# Altium schematic sheet PowerSupply.SchDoc(PowerSupply)
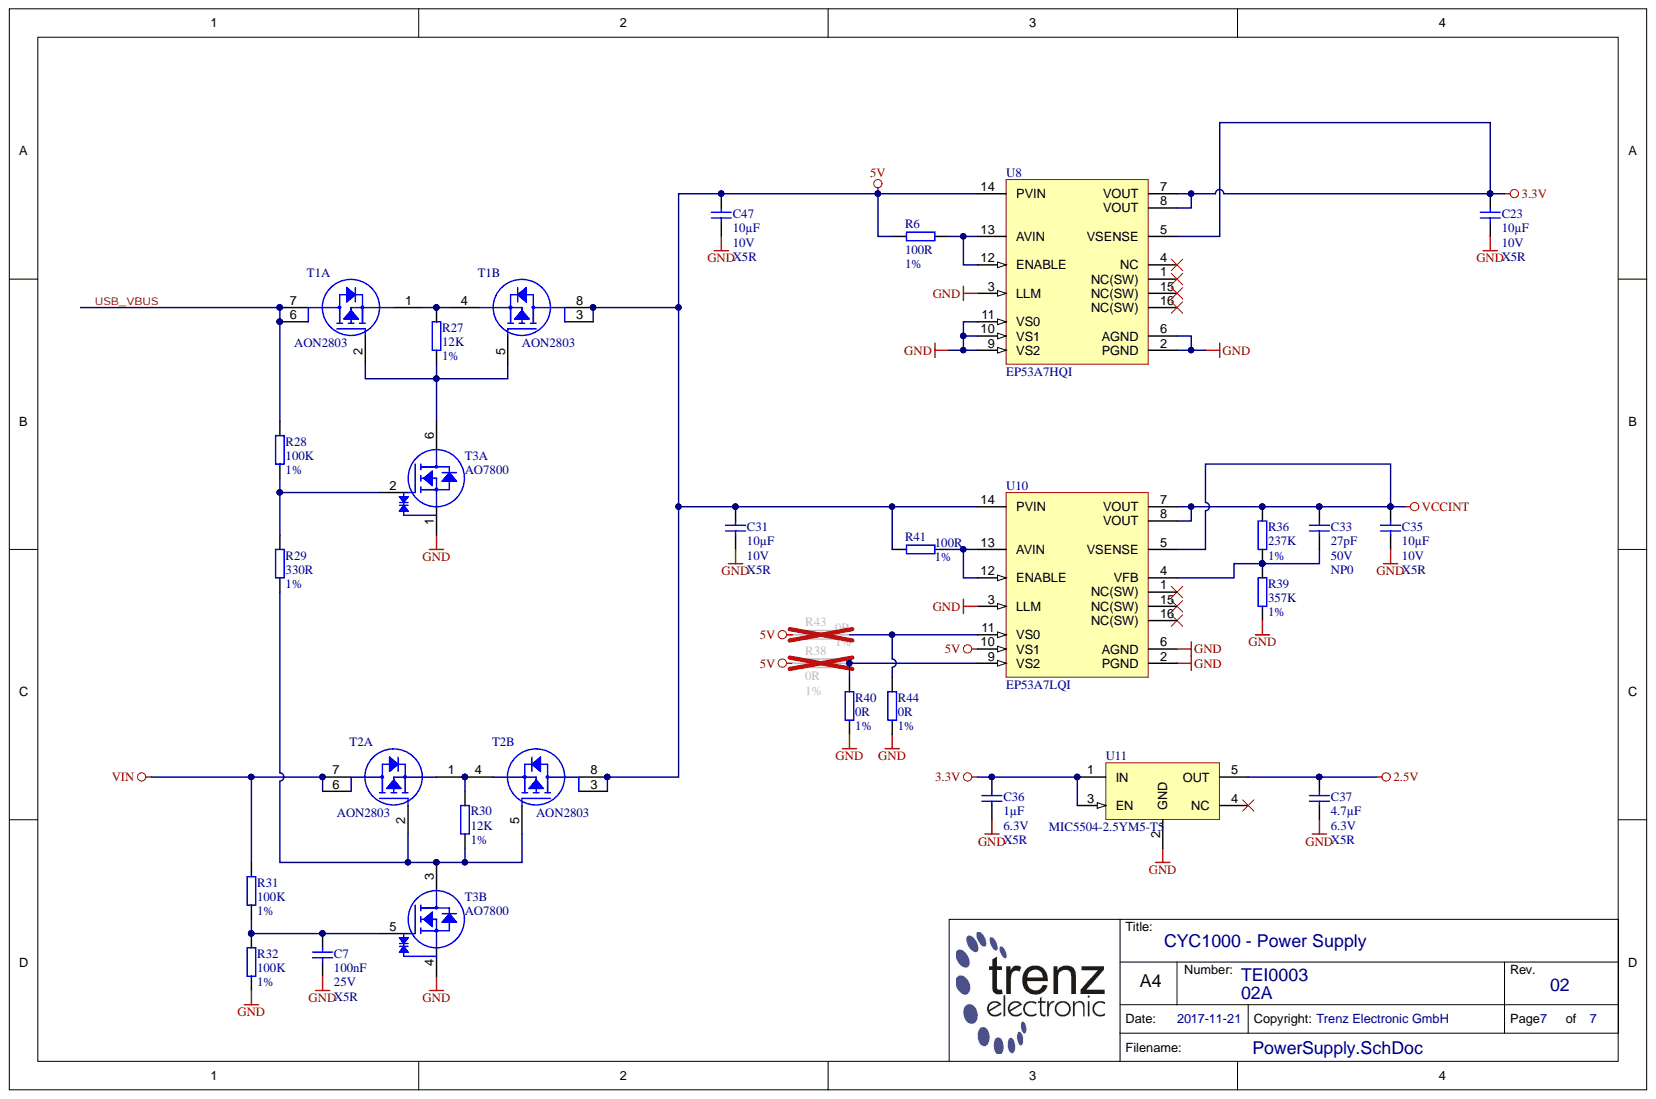
[diagram p0: PowerSupply.SchDoc]

## Parts
C7  100nF  Technology: X5R  Voltage: 25V  [CAPC1005X55N]
C23  10µF  Technology: X5R  Voltage: 10V  [CAPC2012X135N]
C31  10µF  Technology: X5R  Voltage: 10V  [CAPC2012X135N]
C33  27pF  Technology: NP0  Voltage: 50V  [CAPC1005X55N]
C35  10µF  Technology: X5R  Voltage: 10V  [CAPC2012X135N]
C36  1µF  Technology: X5R  Voltage: 6.3V  [CAPC1005X55N]
C37  4.7µF  Technology: X5R  Voltage: 6.3V  [CAPC1005X60N]
C47  10µF  Technology: X5R  Voltage: 10V  [CAPC2012X135N]
R6  100R  Tolerance: 1%  [RESC1005X40N]
R27  12K  Tolerance: 1%  [RESC1005X40N]
R28  100K  Tolerance: 1%  [RESC1005X40N]
R29  330R  Tolerance: 1%  [RESC1005X40N]
R30  12K  Tolerance: 1%  [RESC1005X40N]
R31  100K  Tolerance: 1%  [RESC1005X40N]
R32  100K  Tolerance: 1%  [RESC1005X40N]
R36  237K  Tolerance: 1%  [RESC1005X40N]
R38  0R  Tolerance: 1%  [RESC1005X40N]
R39  357K  Tolerance: 1%  [RESC1005X40N]
R40  0R  Tolerance: 1%  [RESC1005X40N]
R41  100R  Tolerance: 1%  [RESC1005X40N]
R43  0R  Tolerance: 1%  [RESC1005X40N]
R44  0R  Tolerance: 1%  [RESC1005X40N]
T1  AON2803  [SON65P200X200X80-8T10X06]  (parts A,B)
T2  AON2803  [SON65P200X200X80-8T10X06]  (parts A,B)
T3  AO7800  [SOT65P210X110-6N]  (parts A,B)
U8  EP53A7HQI  [EP53F8QI]
U10  EP53A7LQI  [EP53F8QI]
U11  MIC5504-2.5YM5-T5  [SOT95P280X145-5N]

## Nets
2.5V: C37.1 U11.5(OUT)
3.3V: C23.1 C36.1 U8.5(VSENSE) U8.7(VOUT) U8.8(VOUT) U11.1(IN) U11.3(EN)
5V: C31.1 C47.1 R6.1 R38.2 R41.1 R43.2 T1.3 T1.8 T2.3 T2.8 U8.14(PVIN) U10.10(VS1) U10.14(PVIN)
GND: C7.1 C23.2 C31.2 C35.2 C36.2 C37.2 C47.2 R32.2 R39.2 R40.1 R44.1 T3.1 T3.4 U8.2(PGND) U8.3(LLM) U8.6(AGND) U8.9(VS2) U8.10(VS1) U8.11(VS0) U10.2(PGND) U10.3(LLM) U10.6(AGND) U11.2(GND)
VCCINT: C33.1 C35.1 R36.2 U10.5(VSENSE) U10.7(VOUT) U10.8(VOUT)
VIN: R31.1 T2.6 T2.7
USB_VBUS: R28.1 T1.6 T1.7
NetC7_2: C7.2 R31.2 R32.1 T3.5
NetC33_2: C33.2 R36.1 R39.1 U10.4(VFB)
NetR6_2: R6.2 U8.12(ENABLE) U8.13(AVIN)
NetR27_1: R27.1 T1.2 T1.5 T3.6
NetR27_2: R27.2 T1.1 T1.4
NetR28_2: R28.2 R29.2 T3.2
NetR29_1: R29.1 R30.1 T2.2 T2.5 T3.3
NetR30_2: R30.2 T2.1 T2.4
NetR38_1: R38.1 R40.2 U10.9(VS2)
NetR41_2: R41.2 U10.12(ENABLE) U10.13(AVIN)
NetR43_1: R43.1 R44.2 U10.11(VS0)

Not connected: U8 1(NC(SW)) 4(NC) 15(NC(SW)) 16(NC(SW)); U10 1(NC(SW)) 15(NC(SW)) 16(NC(SW)); U11 4(NC)

## Library data of the parts
C7 = 22472: KON 100nF 25V X5R SMD ±10%; Database Table Name: C_1005_0402; Mixed: SMT; Preferred: Yes; Value: 100n; AN: 22472; Case: 1005 (0402); Component Kind: Standard; Library Name: TE.DbLib
C23 C31 C35 C47 = 28014: KON 10µF 10V X5R 10% SMD; Database Table Name: C_2012_0805; Mixed: SMT; Value: 10u; AN: 28014; Case: 2012 (0805); Component Kind: Standard; Library Name: TE.DbLib; Tolerance: 10%
C33 = 23941: KON 27pF NP0 50V SMD; Database Table Name: C_1005_0402; Mixed: SMT; Value: 27p; AN: 23941; Case: 1005 (0402); Component Kind: Standard; Library Name: TE.DbLib
C36 = 23453: KON 1µF 6.3V X5R SMD 10%; Database Table Name: C_1005_0402; Mixed: SMT; Preferred: Yes; Value: 1u; AN: 23453; Case: 1005 (0402); Component Kind: Standard; Library Name: TE.DbLib; Tolerance: 10%
C37 = 24719: KON 4.7µF 6.3V X5R SMD 20%; Database Table Name: C_1005_0402; Mixed: SMT; Preferred: Yes; Value: 4.7u; AN: 24719; Case: 1005 (0402); Component Kind: Standard; Library Name: TE.DbLib; Tolerance: 20%
R6 R41 = 22507: WID SMD 100R 1% 0,063W; Database Table Name: R_1005_0402; Mixed: SMT; Preferred: Yes; Value: 100; AN: 22507; Case: 1005 (0402); Component Kind: Standard; Library Name: TE.DbLib; Technology: TK100
R27 R30 = 22598: WID SMD 12K 1% 0,063W; Database Table Name: R_1005_0402; Mixed: SMT; Preferred: Yes; AN: 22598; Case: 1005 (0402); Component Kind: Standard; Library Name: TE.DbLib; Technology: TK100; Value: 12K
R28 R31 R32 = 22558: WID SMD 100K 1% 0,063W; Database Table Name: R_1005_0402; Mixed: SMT; Preferred: Yes; AN: 22558; Case: 1005 (0402); Component Kind: Standard; Library Name: TE.DbLib; Technology: TK100; Value: 100K
R29 = 22762: WID SMD 330R 1% 0,063W; Database Table Name: R_1005_0402; Mixed: SMT; Preferred: Yes; Value: 330; AN: 22762; Case: 1005 (0402); Component Kind: Standard; Library Name: TE.DbLib; Technology: TK100
R36 = 24549: WID SMD 237K 1% 0,063W; Database Table Name: R_1005_0402; Mixed: SMT; Preferred: Yes; AN: 24549; Case: 1005 (0402); Component Kind: Standard; Library Name: TE.DbLib; Technology: TK100; Value: 237K
R38 R40 R43 R44 = 22738: WID SMD 0R; Database Table Name: R_1005_0402; Mixed: SMT; Preferred: Yes; Value: 0; AN: 22738; Case: 1005 (0402); Component Kind: Standard; Library Name: TE.DbLib; Technology: TK100
R39 = 25437: WID SMD 357K 1% 0,063W; Database Table Name: R_1005_0402; Mixed: SMT; Preferred: Yes; AN: 25437; Case: 1005 (0402); Component Kind: Standard; Library Name: TE.DbLib; Technology: TK100; Value: 357k
T1 T2 = 28041: Database Table Name: TRANSISTOR; Mixed: SMT; Value: -3.8A; Voltage: -20V; AN: 28041; Case: DFN6; Component Kind: Standard; Description: AON2803; H-ART: AON2803; Library Name: TE.DbLib; HelpURL: b:\AD\adlib\datasheet\AON2803\
T3 = 28043: Database Table Name: TRANSISTOR; Mixed: SMT; Value: 0.9A; Voltage: 20V; AN: 28043; Case: SOT23-6; Component Kind: Standard; Description: AO7800; H-ART: AO7800; Library Name: TE.DbLib; HelpURL: b:\AD\adlib\datasheet\AO7800\
U8 = 27996: Database Table Name: DCDC; Mixed: SMT; Preferred: Yes; AN: 27996; Case: QFN16; Component Kind: Standard; Description: EP53A7HQI; H-ART: EP53A7HQI; Library Name: TE.DbLib; Trenz-Stock: Yes; HelpURL: B:\AD\adlib\datasheet\EP53A7HQI
U10 = 28280: Database Table Name: DCDC; Mixed: SMT; Preferred: Yes; AN: 28280; Case: QFN16; Component Kind: Standard; Description: EP53A7LQI; H-ART: EP53A7LQI; Library Name: TE.DbLib; Trenz-Stock: Yes; HelpURL: B:\AD\adlib\datasheet\EP53A7HQI
U11 = 28609: Database Table Name: LREG; Mixed: SMT; Voltage: 2.5V; AN: 28609; Case: SOT23-5; Component Kind: Standard; Description: MIC5504-2.5YM5-T5; H-ART: MIC5504-2.5YM5-T5; Library Name: TE.DbLib; HelpURL: b:\AD\adlib\datasheet\MIC550x\
